# Altium schematic sheet My_Magic_Wand.SchDoc
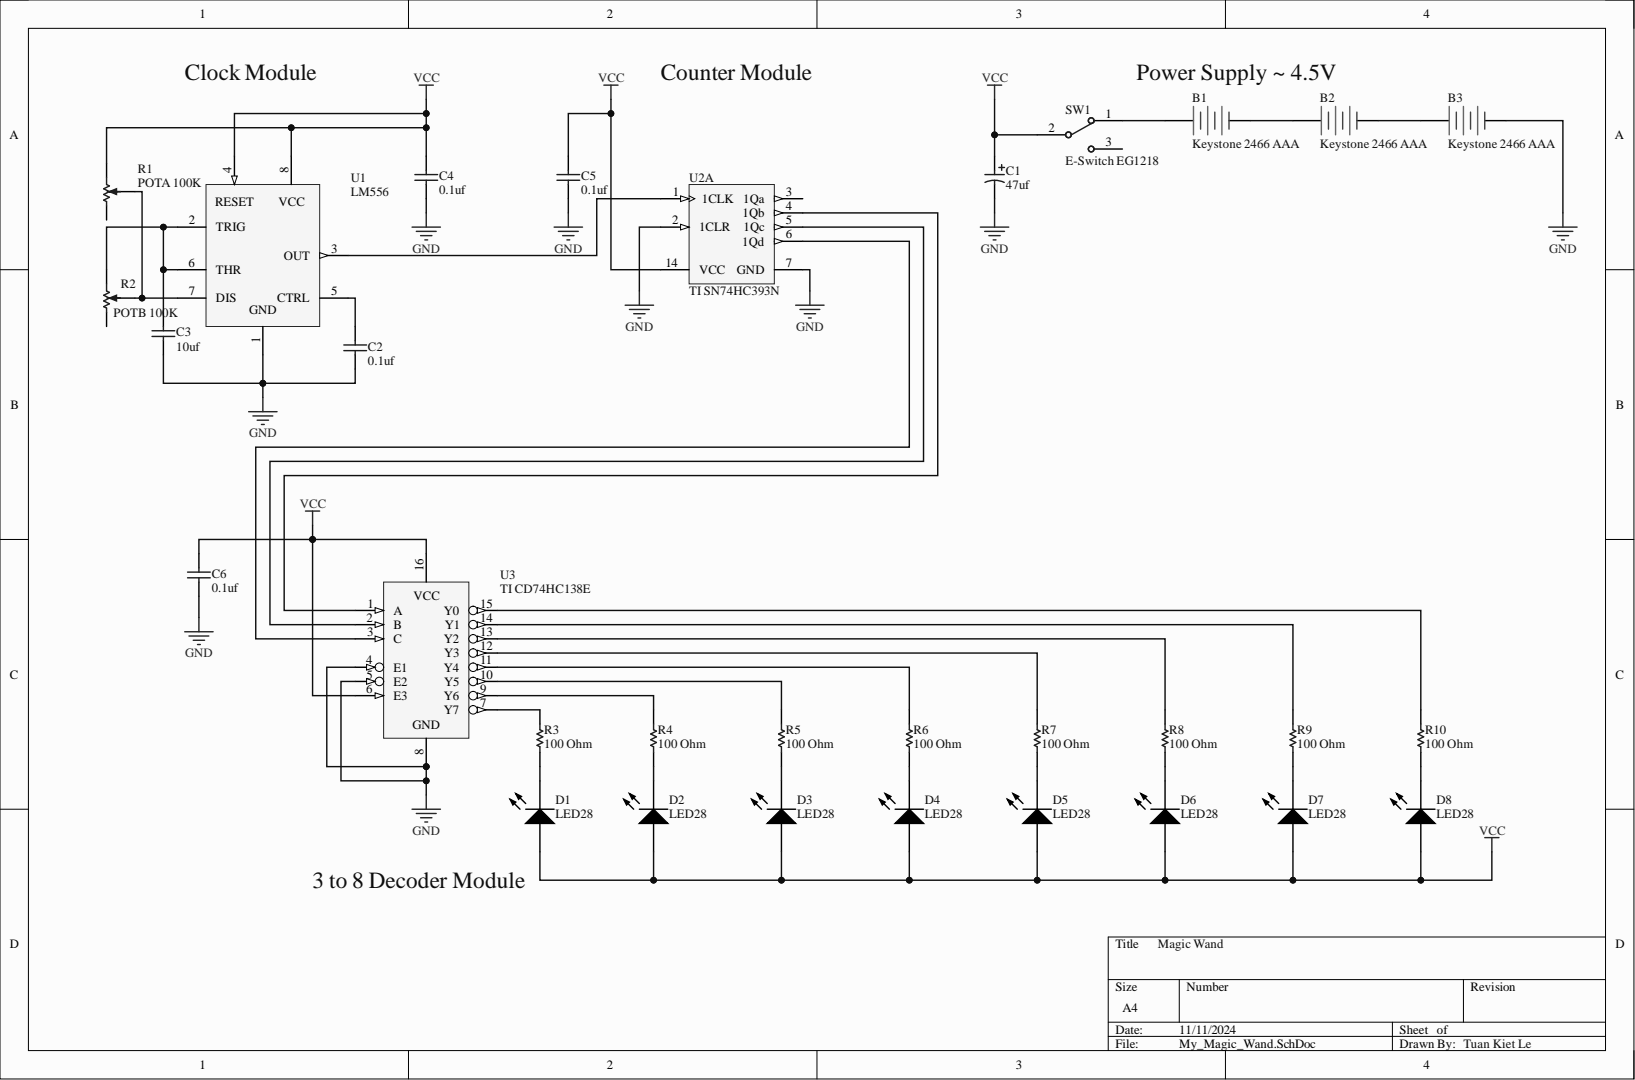
[diagram p0: My_Magic_Wand.SchDoc]

## Parts
B1  Keystone 2466 AAA  Manufacturer Part Number: 2466  [PCB-2466]
B2  Keystone 2466 AAA  Manufacturer Part Number: 2466  [PCB-2466]
B3  Keystone 2466 AAA  Manufacturer Part Number: 2466  [PCB-2466]
C1  47uf  [PCB-xswzn1jk2ejoevwvaoxh-1]
C2  0.1uf  [PCB-681ezhisbnfubqcttqok-1]
C3  10uf  [PCB-681ezhisbnfubqcttqok-1]
C4  0.1uf  [PCB-681ezhisbnfubqcttqok-1]
C5  0.1uf  [PCB-681ezhisbnfubqcttqok-1]
C6  0.1uf  [PCB-681ezhisbnfubqcttqok-1]
D1  LED28  [PCB-t8a8bkv5t5yzrq5f3vco-1]
D2  LED28  [PCB-t8a8bkv5t5yzrq5f3vco-1]
D3  LED28  [PCB-t8a8bkv5t5yzrq5f3vco-1]
D4  LED28  [PCB-t8a8bkv5t5yzrq5f3vco-1]
D5  LED28  [PCB-t8a8bkv5t5yzrq5f3vco-1]
D6  LED28  [PCB-t8a8bkv5t5yzrq5f3vco-1]
D7  LED28  [PCB-t8a8bkv5t5yzrq5f3vco-1]
D8  LED28  [PCB-t8a8bkv5t5yzrq5f3vco-1]
R1  POTA 100K  [PCB-ctg1gr7cbxc3jh114rn7-1]
R2  POTB 100K  [PCB-ctg1gr7cbxc3jh114rn7-1]
R3  100 Ohm  [PCB-uflnvl65vyu2u3zyxdd9-1]
R4  100 Ohm  [PCB-uflnvl65vyu2u3zyxdd9-1]
R5  100 Ohm  [PCB-uflnvl65vyu2u3zyxdd9-1]
R6  100 Ohm  [PCB-uflnvl65vyu2u3zyxdd9-1]
R7  100 Ohm  [PCB-uflnvl65vyu2u3zyxdd9-1]
R8  100 Ohm  [PCB-uflnvl65vyu2u3zyxdd9-1]
R9  100 Ohm  [PCB-uflnvl65vyu2u3zyxdd9-1]
R10  100 Ohm  [PCB-uflnvl65vyu2u3zyxdd9-1]
SW1  E-Switch EG1218  Manufacturer Part Number: EG1218  [ESWI-EG1218_V]
U1  LM556  [PCC-0018-00132-4]
U2  TI SN74HC393N  Manufacturer Part Number: SN74HC393N  [PCB-te1ukpb7ypv15gwynu8x-1]  (part A)
U3  TI CD74HC138E  Manufacturer Part Number: CD74HC138E  [PCB-iqyivjlmuk83cucpld0n-1]

## Nets
GND: B3.2 C1.2 C2.1 C3.1 C4.1 C5.1 C6.1 U1.1 U2.2(1CLR) U2.7(GND) U3.4(E1) U3.5(E2) U3.8
VCC: C1.1 C4.2 C5.2 C6.2 D1.1 D2.1 D3.1 D4.1 D5.1 D6.1 D7.1 D8.1 R1.1 SW1.2 U1.4 U1.8 U2.14(VCC) U3.6(E3) U3.16
NetB1_1: B1.1 SW1.1
NetB1_2: B1.2 B2.1
NetB2_2: B2.2 B3.1
NetC2_2: C2.2 U1.5(CTRL)
NetC3_2: C3.2 R2.1 U1.2(TRIG) U1.6(THR)
NetD1_2: D1.2 R3.1
NetD2_2: D2.2 R4.1
NetD3_2: D3.2 R5.1
NetD4_2: D4.2 R6.1
NetD5_2: D5.2 R7.1
NetD6_2: D6.2 R8.1
NetD7_2: D7.2 R9.1
NetD8_2: D8.2 R10.1
NetR1_2: R1.2 R2.2 U1.7(DIS)
NetR3_2: R3.2 U3.7(Y7)
NetR4_2: R4.2 U3.9(Y6)
NetR5_2: R5.2 U3.10(Y5)
NetR6_2: R6.2 U3.11(Y4)
NetR7_2: R7.2 U3.12(Y3)
NetR8_2: R8.2 U3.13(Y2)
NetR9_2: R9.2 U3.14(Y1)
NetR10_2: R10.2 U3.15(Y0)
NetU1_3: U1.3(OUT) U2.1(1CLK)
NetU2_4: U2.4(1Qb) U3.1(A)
NetU2_5: U2.5(1Qc) U3.2(B)
NetU2_6: U2.6(1Qd) U3.3(C)

Not connected: R1 3; R2 3; SW1 3; U2 3(1Qa)

## Library data of the parts
B1-B3 = CMP-3612-20: Battery holder, AAA, 1 cell, PC mount; Manufacturer Name: Keystone Electronics; Lead-Free Status: Lead Free; Component Kind: Standard; Contact Plating: Nickel; Library Name: My Personal Space; Material: Plastic; Octopart Url: https://octopart.com/2466-keystone-65856; OctopartID: fe0e3705da2821c9; RoHS: Compliant; Supplier Name: Digikey; Supplier PN: 36-2466-ND; Supplier URL: https://www.digikey.ca/product-detail/en/keystone-electronics/2466/36-2466-ND/303815
C1 = CMP-b868704b0d3c67af-17: Electrolytic capacitor Radial lead 2.5 mm 10 µF 16 V 20 % (Ø) 4 mm Panasonic EEA-GA1C100H 1 pc(s); Component Kind: Standard; Library Name: My Personal Space; OctopartID: b868704b0d3c67af
C2-C6 = CMP-e4d32f8040453b14-13: Multilayer Ceramic Capacitor, Gold Max, C320 Series, 0.1 - F, - 10%, X7r, 50 V, Radial Leaded; Manufacturer Part Number: C320C104K5R5TA; Capacitance: 100 nF; Lead Length: 7.00 mm; Lead-Free Status: Lead Free; Voltage Rating (DC): 50.0 V; Capacitance Tolerance: ±10%; Component Kind: Standard; Dielectric Characteristic: X7R; Library Name: My Personal Space; Mounting Style: Through Hole; Octopart URL: https://octopart.com/c320c104k5r5ta-kemet-39420400; OctopartID: e4d32f8040453b14; Operating Temperature: 125 °C (max); Packaging: Bulk; REACH SVHC Compliance: No SVHC; RoHS: Compliant; Size-Height: 6.60 mm; Size-Length: 5.08 mm
D1-D8 = CMP-e4882d82c7303b4f-8: LED; PCB; Green; 30mcd; 0.232In.; T-1-3/4; 150mA; 2.2V; 565nm; 60deg; GaP; Diffused; Component Kind: Standard; Library Name: My Personal Space
R1 R2 = CMP-25fbe8b26973fa3c-1: Res Cermet Trimmer 100K Ohm 10% 1/4W 12(Elec)Turn 1.8mm (6.4 X 4 X 7.7mm) Pin Thru-Hole Bulk; Manufacturer Part Number: PV37W104C01B00; Lead-Free Status: Lead Free; Power Rating: 250 mW; Resistance: 100 kΩ; Color: Gray, Dark; Component Kind: Standard; Library Name: My Personal Space; Mounting Style: Through Hole; Octopart URL: http://octopart.com/pv37w104c01b00-bourns-71526894; OctopartID: 25fbe8b26973fa3c; Packaging: Bulk; Resistance Tolerance: ±10%; RoHS: Compliant; Size-Height: 6.70 mm; Size-Length: 6.40 mm; Size-Width: 4.00 mm; Weight: 340 mg
R3-R10 = CMP-10464714-8: RES 100 OHM 1/4W 5% AXIAL; Component Kind: Standard; Library Name: My Personal Space
SW1 = CMP-9a5a5340a2f5459d-17: Slide Switch, 500 V, -20 to 70 degC, 3-Pin THD, RoHS; Actuator Type: Standard; Contacts Type: SPDT; Current Rating: 200 mA; Lead-Free Status: Lead Free; Number of Positions: 2; Component Kind: Standard; Contact Material: Copper Alloy; Contact Plating: Silver; DatasheetVersion: Oct-15; Library Name: My Personal Space; Mounting Style: PCB, Through Hole; Octopart Url: http://octopart.com/eg1218-e-switch-1056201; OctopartID: 9a5a5340a2f5459d; Orientation: Vertical; PackageDescription: 3-Pin Through Hole Device, Body 11.6 x 4 mm, Pitch 2.5 mm; PackageReference: EG1218; Packaging: Bulk; RoHS: Compliant; Seller Landing  Url: https://octopart-clicks.com/click/track?country=US&ak=8157f166&sig=0e9adab&sid=459&ppid=1056201&vpid=1179261&ct=offers; Size-Height: 5.40 mm; Size-Length: 2.03 mm; Size-Width: 4.00 mm
U1 = CMP-d8c011cae97e0707-14: Dvostruko tajmer kolo, SOIC14; Manufacturer Part Number: TLC555CP; Current Rating: 250 A; Frequency: 2.10 MHz; Lead-Free Status: Lead Free; Output Current: 15.0 mA; Quiescent Current: 250 µA; Supply Voltage (DC): 2.00 V (min); Case/Package: PDIP; Component Kind: Standard; Library Name: My Personal Space; Lifecycle Status: Active; Mounting Style: PTH; Number of Pins: 8; Octopart URL: http://octopart.com/tlc555cp-texas+instruments-5336; OctopartID: d8c011cae97e0707; Packaging: Tube; REACH SVHC Compliance: No SVHC; RoHS: Compliant
U2 = CMP-3c53f5596157e394-2: Texas Instruments - SN74HC393N - Counter/Divider Dual 4-Bit Binary UP 14-Pin PDIP Tube; Clock Speed: 60.0 MHz; Lead-Free Status: Lead Free; Number of Bits: 4; Output Current Drive: -1.00 mA; Supply Voltage (DC): 2.00 V to 6.00 V; Voltage Nodes: 2.00 V, 6.00 V, 5.00 V; Case/Package: PDIP; Component Kind: Standard; ComponentLink1Description: New link; Library Name: My Personal Space; Lifecycle Status: Active; Mounting Style: Through Hole; Number of Pins: 14; Octopart URL: https://octopart.com/sn74hc393n-texas+instruments-30818; OctopartID: 3c53f5596157e394; Operating Temperature: -40.0 °C to 85.0 °C; Packaging: Tube; REACH SVHC Compliance: No SVHC; RoHS: Compliant
U3 = CMP-1166716-8: TEXAS INSTRUMENTS   CD74HC138E   Logic Type:Decoder / Demultiplexer; Lead-Free Status: Lead Free; Number of Bits: 8; Number of Circuits: 1; Operating Supply Voltage: 2 V to 6 V; Operating Supply Voltage (typical): 2.5V / 3.3V / 5.0V; Output Current: 5.2 mA; Propagation Delay: 265 ns; Component Kind: Standard; Library Name: My Personal Space; Lifecycle Status: Active; Manufacturer: Texas Instruments; Mounting Style: Through Hole; Number of Outputs: 8; Number of Pins: 16; Octopart Url: https://octopart.com/cd74hc138e-harris-4046706; OctopartID: 2c46e54acdf8f381; Operating Temperature: -55C to 125C; Package: PDIP; Packaging: Tube; REACH SVHC Compliance: No SVHC; RoHS: Compliant
